# Altium schematic sheet STSPIN32F0A ESC3.SchDoc
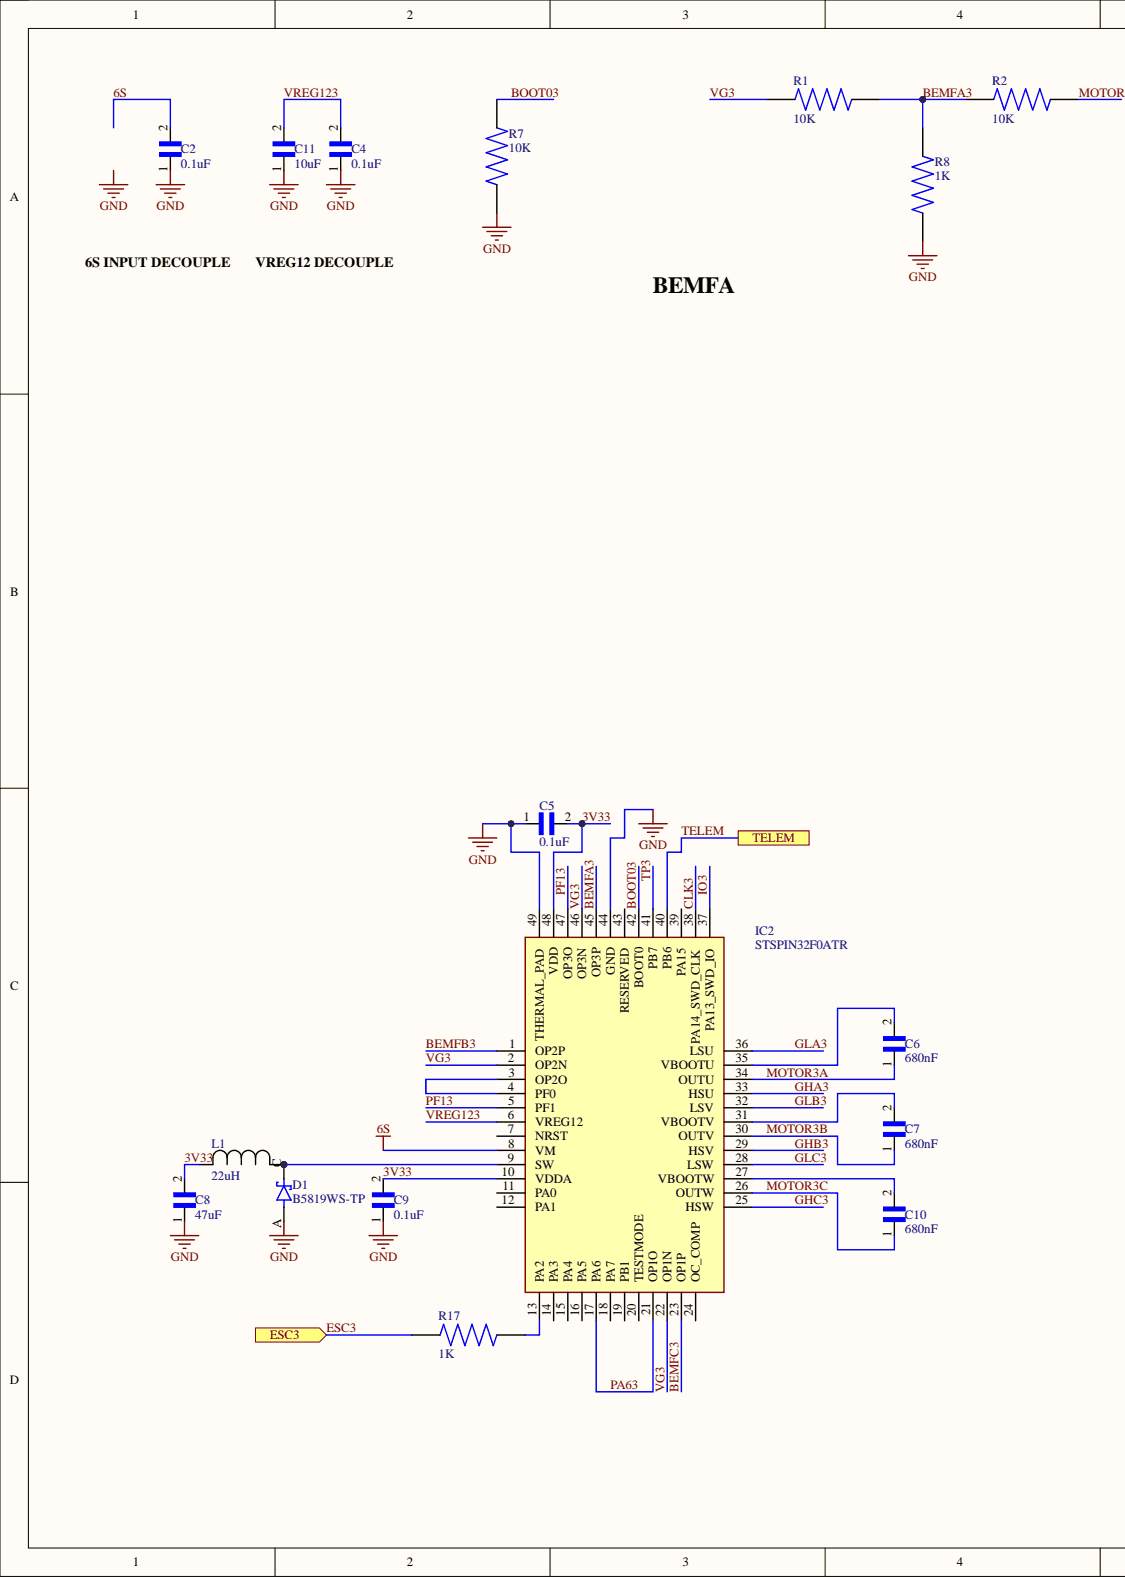
[diagram p0: STSPIN32F0A ESC3.SchDoc, left]
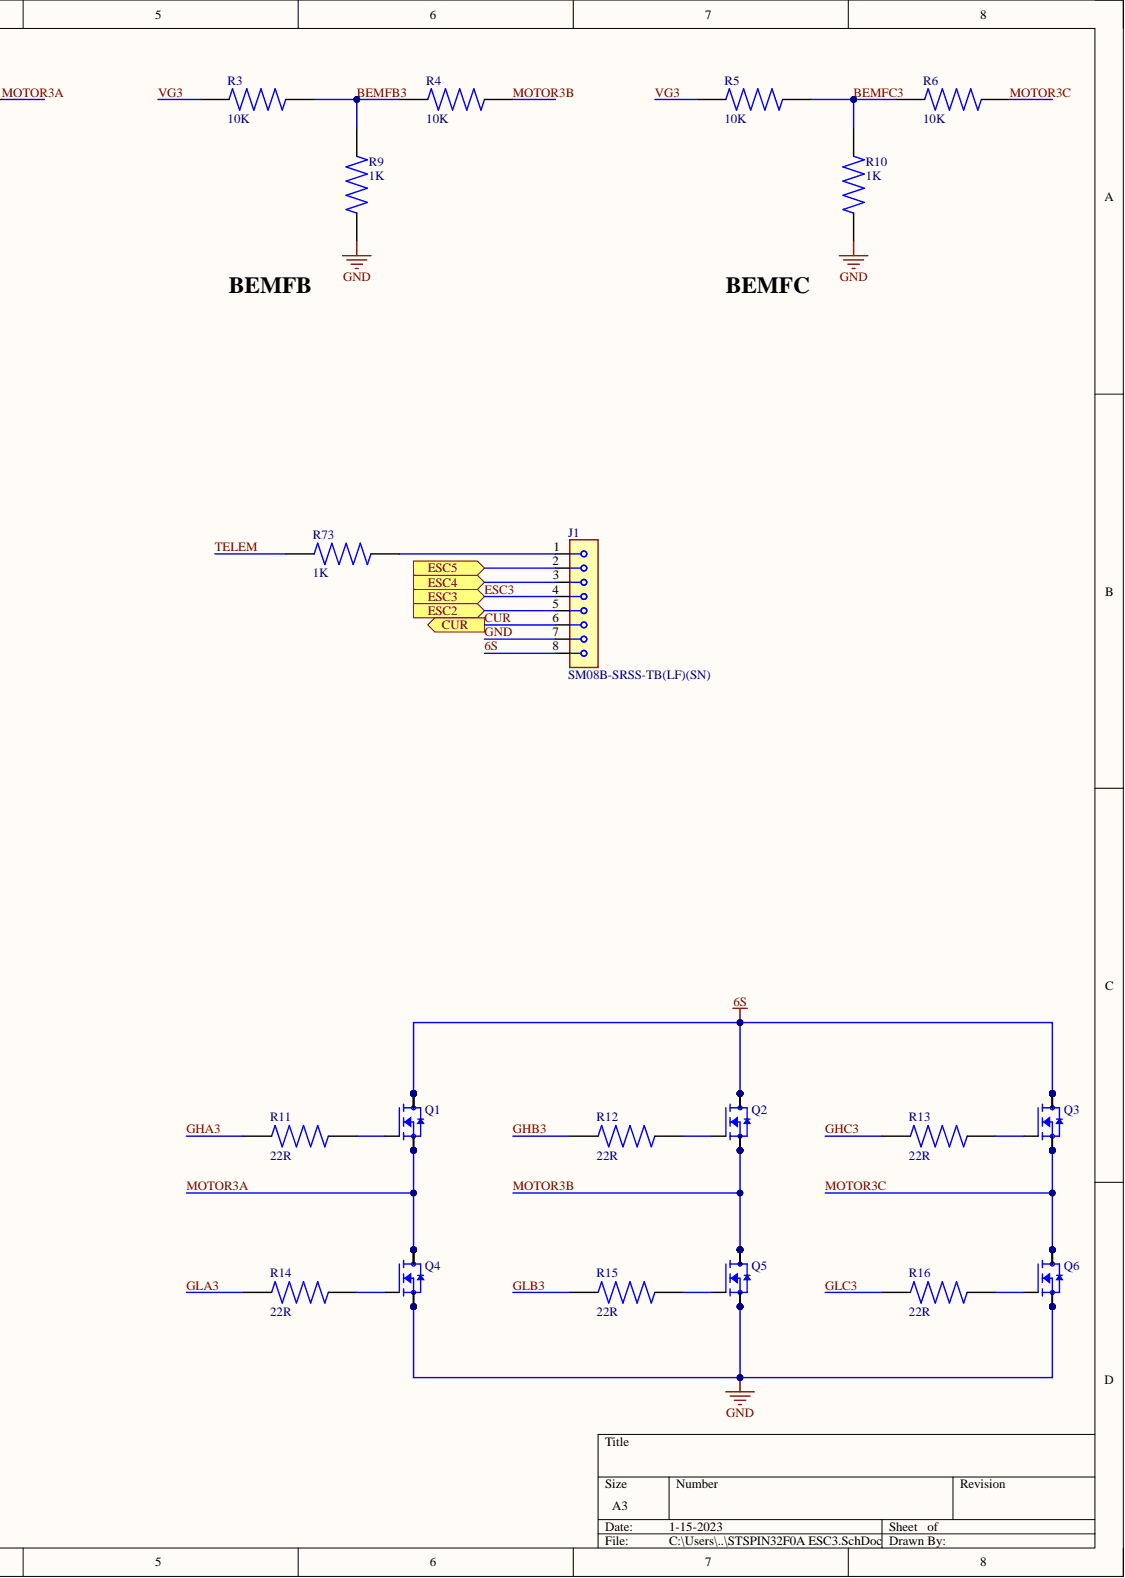
[diagram p1: STSPIN32F0A ESC3.SchDoc, right]

## Parts
C2  0.1uF  [CAPC1005X55N]
C4  0.1uF  [CAPC1005X55N]
C5  0.1uF  [CAPC1005X55N]
C6  680nF  [CAPC1005X55N]
C7  680nF  [CAPC1005X55N]
C8  47uF  [CAPC1608X90N]
C9  0.1uF  [CAPC1005X55N]
C10  680nF  [CAPC1005X55N]
C11  10uF  [CAPC1608X90N]
D1  B5819WS-TP  [SOD2512X115N]
IC2  STSPIN32F0ATR  [QFN50P700X700X100-49N-D]
J1  SM08B-SRSS-TB(LF)(SN)  [JST_SM08B-SRSS-TB(LF)(SN)]
L1  22uH  [IND_BOURNS_SRN3015]
Q1  TPHR8504PL,L1Q  [TRANS_TPHR8504PL,L1Q]  (value not drawn)
Q2  TPHR8504PL,L1Q  [TRANS_TPHR8504PL,L1Q]  (value not drawn)
Q3  TPHR8504PL,L1Q  [TRANS_TPHR8504PL,L1Q]  (value not drawn)
Q4  TPHR8504PL,L1Q  [TRANS_TPHR8504PL,L1Q]  (value not drawn)
Q5  TPHR8504PL,L1Q  [TRANS_TPHR8504PL,L1Q]  (value not drawn)
Q6  TPHR8504PL,L1Q  [TRANS_TPHR8504PL,L1Q]  (value not drawn)
R1  10K  [RESC1005X37N]
R2  10K  [RESC1005X37N]
R3  10K  [RESC1005X37N]
R4  10K  [RESC1005X37N]
R5  10K  [RESC1005X37N]
R6  10K  [RESC1005X37N]
R7  10K  [RESC1005X37N]
R8  1K  [RESC1005X37N]
R9  1K  [RESC1005X37N]
R10  1K  [RESC1005X37N]
R11  22R  [RESC1005X37N]
R12  22R  [RESC1005X37N]
R13  22R  [RESC1005X37N]
R14  22R  [RESC1005X37N]
R15  22R  [RESC1005X37N]
R16  22R  [RESC1005X37N]
R17  1K  [RESC1005X37N]
R73  1K  [RESC1005X37N]

## Ports
CUR, ESC2, ESC3, ESC4, ESC5, TELEM

## Nets
3V33: C5.2 C8.2 C9.2 IC2.10(VDDA) IC2.48(VDD) L1.2
6S: C2.2 IC2.8(VM) J1.8 Q1.5 Q1.6 Q1.7 Q1.8 Q1.9 Q2.5 Q2.6 Q2.7 Q2.8 Q2.9 Q3.5 Q3.6 Q3.7 Q3.8 Q3.9
BEMFA3: IC2.45(OP3P) R1.1 R2.2 R8.1
BEMFB3: IC2.1(OP2P) R3.1 R4.2 R9.1
BEMFC3: IC2.23(OP1P) R5.1 R6.2 R10.1
BOOT03: IC2.42(BOOT0) R7.2
CLK3: IC2.38(PA14_SWD_CLK)
CUR: J1.6 ; port CUR
ESC2: J1.5 ; port ESC2
ESC3: J1.4 R17.1 ; port ESC3
ESC4: J1.3 ; port ESC4
ESC5: J1.2 ; port ESC5
GHA3: IC2.33(HSU) R11.2
GHB3: IC2.29(HSV) R12.2
GHC3: IC2.25(HSW) R13.2
GLA3: IC2.36(LSU) R14.2
GLB3: IC2.32(LSV) R15.2
GLC3: IC2.28(LSW) R16.2
GND: C2.1 C4.1 C5.1 C8.1 C9.1 C11.1 D1.A IC2.44(GND) IC2.49(THERMAL_PAD) J1.7 Q4.1 Q4.2 Q4.3 Q5.1 Q5.2 Q5.3 Q6.1 Q6.2 Q6.3 R7.1 R8.2 R9.2 R10.2
IO3: IC2.37(PA13_SWD_IO)
MOTOR3A: C6.1 IC2.34(OUTU) Q1.1 Q1.2 Q1.3 Q4.5 Q4.6 Q4.7 Q4.8 Q4.9 R2.1
MOTOR3B: C7.1 IC2.30(OUTV) Q2.1 Q2.2 Q2.3 Q5.5 Q5.6 Q5.7 Q5.8 Q5.9 R4.1
MOTOR3C: C10.1 IC2.26(OUTW) Q3.1 Q3.2 Q3.3 Q6.5 Q6.6 Q6.7 Q6.8 Q6.9 R6.1
PA63: IC2.17(PA6) IC2.21(OP1O)
PF13: IC2.5(PF1) IC2.47(OP3O)
TELEM: IC2.40(PB6) R73.1 ; port TELEM
TP3: IC2.41(PB7)
VG3: IC2.2(OP2N) IC2.22(OP1N) IC2.46(OP3N) R1.2 R3.2 R5.2
VREG123: C4.2 C11.2 IC2.6(VREG12)
NetC6_2: C6.2 IC2.35(VBOOTU)
NetC7_2: C7.2 IC2.31(VBOOTV)
NetC10_2: C10.2 IC2.27(VBOOTW)
NetD1_C: D1.C IC2.9(SW) L1.1
NetIC2_3: IC2.3(OP2O) IC2.4(PF0)
NetIC2_13: IC2.13(PA2) R17.2
NetJ1_1: J1.1 R73.2
NetQ1_4: Q1.4 R11.1
NetQ2_4: Q2.4 R12.1
NetQ3_4: Q3.4 R13.1
NetQ4_4: Q4.4 R14.1
NetQ5_4: Q5.4 R15.1
NetQ6_4: Q6.4 R16.1

Not connected: IC2 7(NRST) 11(PA0) 12(PA1) 14(PA3) 15(PA4) 16(PA5) 18(PA7) 19(PB1) 20(TESTMODE) 24(OC_COMP) 39(PA15) 43(RESERVED)

## Library data of the parts
C2 C4-C7 C9 C10 = GRM1555C1H222JA01D: Availability: Unavailable; Component Kind: Standard; Library Name: GRM1555C1H222JA01D.IntLib; MP: GRM1555C1H222JA01D; Package: None; Price: None
C8 C11 = GRM188R71E224JA88D: SMD Capacitor X7R(EIA) with Capacitance: 0.22uF Tol. 5%. Rated Voltage: 25Vdc; Availability: Unavailable; Component Kind: Standard; Library Name: GRM188R71E224JA88D.IntLib; MP: GRM188R71E224JA88D; Package: None; Price: None
D1 = B5819WS-TP: MF: {'manufacturer': u'Micro Commercial Components'}; Availability: Unavailable; Component Kind: Standard; Library Name: SnapEDA_Library.IntLib; MP: B5819WS-TP; Package: None; Price: None
IC2 = STSPIN32F0ATR: Integrated Circuit; Manufacturer_Name: STMicroelectronics; Arrow Part Number: STSPIN32F0ATR; Arrow Price/Stock: https://www.arrow.com/en/products/stspin32f0atr/stmicroelectronics; Component Kind: Standard; Datasheet Link: http://www.st.com/content/ccc/resource/technical/document/datasheet/group3/3d/88/0f/d1/3f/0c/49/80/DM00406030/files/DM00406030.pdf/jcr:content/translations/en.DM00406030.pdf; Height: 1mm; Library Name: SamacSys.SchLib; Manufacturer_Part_Number: STSPIN32F0ATR; Mouser Part Number: 511-STSPIN32F0ATR; Mouser Price/Stock: https://www.mouser.co.uk/ProductDetail/STMicroelectronics/STSPIN32F0ATR?qs=1mbolxNpo8flc7yUpKWOVQ%3D%3D
J1 = SM08B-SRSS-TB(LF)(SN): SH Series 8 Position 1 mm Pitch Surface Mount Side Entry Shrouded Header; Availability: Unavailable; Component Kind: Standard; Library Name: SM08B-SRSS-TB_LF__SN_.IntLib; MP: SM08B-SRSS-TB(LF)(SN); Package: None; Price: None
L1 = SRN3015-220M: No Description Available; Mfr_Name: Bourns Electronics; Type: DEV; Component Kind: Standard; Digi-Key_Part_Number_1: SRN3015-220MCT-ND; Digi-Key_Part_Number_2: SRN3015-220MDKR-ND; Digi-Key_Part_Number_3: SRN3015-220MTR-ND; Library Name: SRN3015-220M.IntLib; Manufacturer_Part_Number: SRN3015-220M; RefDes: RefDes; Copyright: Copyright (C) 2022 Ultra Librarian. All rights reserved.
Q1-Q6 = TPHR8504PL,L1Q: N-Channel 40V 150A (Tc) 1W (Ta), 170W (Tc) Surface Mount 8-SOP Advance (5x5); MF: Toshiba Semiconductor; Availability: Unavailable; Component Kind: Standard; Library Name: TPHR8504PL_L1Q.IntLib; MP: TPHR8504PL,L1Q; Package: SOP-8 Toshiba; Price: None; SnapEDA_Link: https://www.snapeda.com/parts/TPHR8504PL,L1Q/Toshiba%20Semiconductor%20and%20Storage/view-part/604439/?ref=snap
R1-R17 R73 = RE0402BRE07330KL: MF: {'manufacturer': u'Yageo'}; Availability: Unavailable; Component Kind: Standard; Library Name: SnapEDA_Library.IntLib; MP: RE0402BRE07330KL; Package: None; Price: None
